# Altium schematic sheet UI.SchDoc
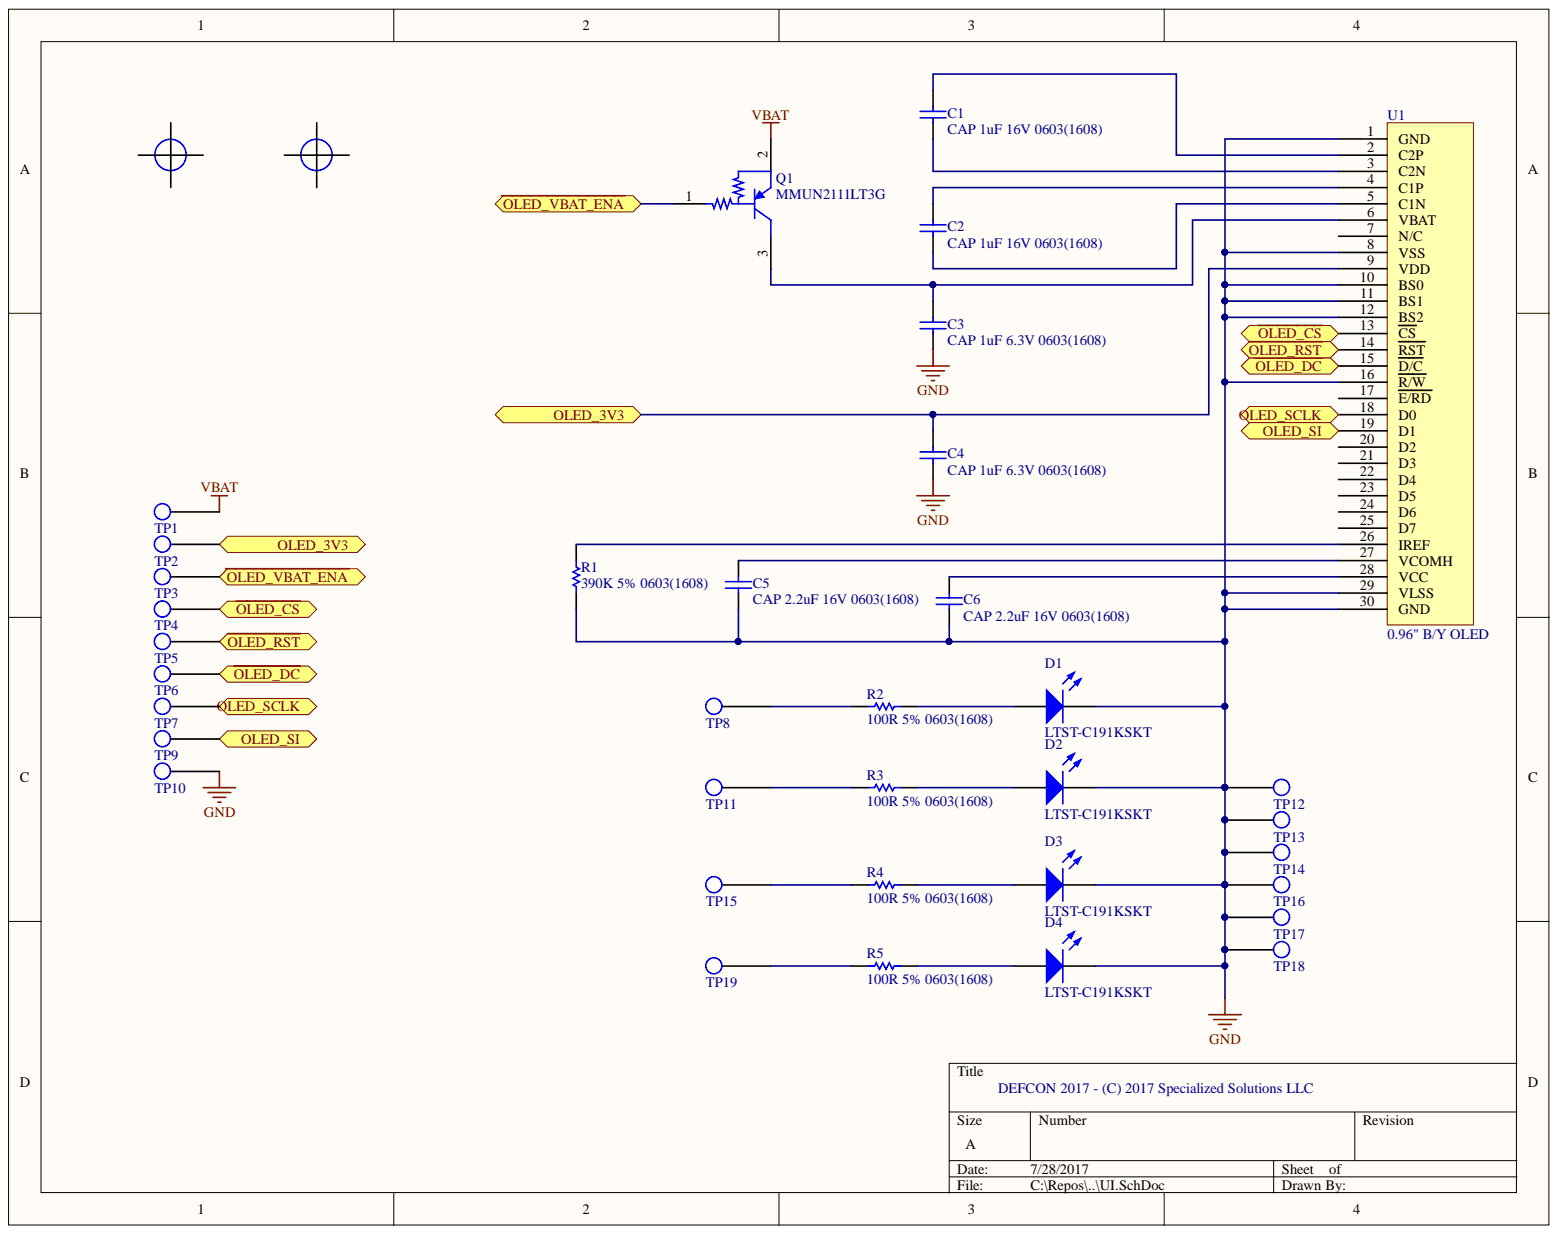
[diagram p0: UI.SchDoc]

## Parts
C1  CAP 1uF 16V 0603(1608)  value 1uF  [CAPC0603(1608)100_L]
C2  CAP 1uF 16V 0603(1608)  value 1uF  [CAPC0603(1608)100_L]
C3  CAP 1uF 6.3V 0603(1608)  value 1uF  [CAPC0603(1608)100_L]
C4  CAP 1uF 6.3V 0603(1608)  value 1uF  [CAPC0603(1608)100_L]
C5  CAP 2.2uF 16V 0603(1608)  value 2.2uF  [CAPC0603(1608)55_L]
C6  CAP 2.2uF 16V 0603(1608)  value 2.2uF  [CAPC0603(1608)55_L]
D1  LTST-C191KSKT  [DIOC1608X07N]
D2  LTST-C191KSKT  [DIOC1608X07N]
D3  LTST-C191KSKT  [DIOC1608X07N]
D4  LTST-C191KSKT  [DIOC1608X07N]
Q1  MMUN2111LT3G  [ONSC-SOT-23-3-318-08_V]
R1  390K 5% 0603(1608)  [RESC0603(1608)_N]
R2  100R 5% 0603(1608)  [RESC0603(1608)_L]
R3  100R 5% 0603(1608)  [RESC0603(1608)_L]
R4  100R 5% 0603(1608)  [RESC0603(1608)_L]
R5  100R 5% 0603(1608)  [RESC0603(1608)_L]
TP1  Test Point  [TP Castellation]  (value not drawn)  (no BOM)
TP2  Test Point  [TP Castellation]  (value not drawn)  (no BOM)
TP3  Test Point  [TP Castellation]  (value not drawn)  (no BOM)
TP4  Test Point  [TP Castellation]  (value not drawn)  (no BOM)
TP5  Test Point  [TP Castellation]  (value not drawn)  (no BOM)
TP6  Test Point  [TP Castellation]  (value not drawn)  (no BOM)
TP7  Test Point  [TP Castellation]  (value not drawn)  (no BOM)
TP8  Test Point  [TP Castellation]  (value not drawn)  (no BOM)
TP9  Test Point  [TP Castellation]  (value not drawn)  (no BOM)
TP10  Test Point  [TP Castellation]  (value not drawn)  (no BOM)
TP11  Test Point  [TP Castellation]  (value not drawn)  (no BOM)
TP12  Test Point  [TP Castellation]  (value not drawn)  (no BOM)
TP13  Test Point  [TP Castellation]  (value not drawn)  (no BOM)
TP14  Test Point  [TP Castellation]  (value not drawn)  (no BOM)
TP15  Test Point  [TP Castellation]  (value not drawn)  (no BOM)
TP16  Test Point  [TP Castellation]  (value not drawn)  (no BOM)
TP17  Test Point  [TP Castellation]  (value not drawn)  (no BOM)
TP18  Test Point  [TP Castellation]  (value not drawn)  (no BOM)
TP19  Test Point  [TP Castellation]  (value not drawn)  (no BOM)
U1  0.96" B/Y OLED  [0.96" B/Y OLED]
  Fiducial  [Fiducial]  (value not drawn)  (no BOM)
  Fiducial  [Fiducial]  (value not drawn)  (no BOM)

## Ports
OLED_3V3, OLED_SCLK, OLED_SI, ~{OLED_CS}, ~{OLED_DC}, ~{OLED_RST}, ~{OLED_VBAT_ENA}

## Nets
GND: C3.1 C4.1 C5.1 C6.1 D1.1 D2.1 D3.1 D4.1 R1.1 TP10.1 TP12.1 TP13.1 TP14.1 TP16.1 TP17.1 TP18.1 U1.1(GND) U1.8(VSS) U1.10(BS0) U1.11(BS1) U1.12(BS2) U1.16(R/W) U1.29(VLSS) U1.30(GND)
VBAT: Q1.2 TP1.1
~{OLED_CS}: TP4.1 U1.13(CS) ; port ~{OLED_CS}
~{OLED_DC}: TP6.1 U1.15(D/C) ; port ~{OLED_DC}
~{OLED_RST}: TP5.1 U1.14(RST) ; port ~{OLED_RST}
~{OLED_VBAT_ENA}: Q1.1 TP3.1 ; port ~{OLED_VBAT_ENA}
OLED_3V3: C4.2 TP2.1 U1.9(VDD) ; port OLED_3V3
OLED_SCLK: TP7.1 U1.18(D0) ; port OLED_SCLK
OLED_SI: TP9.1 U1.19(D1) ; port OLED_SI
NetC1_1: C1.1 U1.3(C2N)
NetC1_2: C1.2 U1.2(C2P)
NetC2_1: C2.1 U1.5(C1N)
NetC2_2: C2.2 U1.4(C1P)
NetC3_2: C3.2 Q1.3 U1.6(VBAT)
NetC5_2: C5.2 U1.27(VCOMH)
NetC6_2: C6.2 U1.28(VCC)
NetD1_2: D1.2 R2.2
NetD2_2: D2.2 R3.2
NetD3_2: D3.2 R4.2
NetD4_2: D4.2 R5.2
NetR1_2: R1.2 U1.26(IREF)
NetR2_1: R2.1 TP8.1
NetR3_1: R3.1 TP11.1
NetR4_1: R4.1 TP15.1
NetR5_1: R5.1 TP19.1

Not connected: U1 7(N/C) 17(E/RD) 20(D2) 21(D3) 22(D4) 23(D5) 24(D6) 25(D7)

## Library data of the parts
C1 C2 = CMP-1035-02738-1: CAP 1uF 16V ±20% 0603 (1608 Metric) Thickness 1mm SMD; Case-EIA: 0603; Case-Metric: 1608; Tolerance: Â±20%; Component Kind: Standard; Library Name: Altium Content Vault; Max Thickness: 1 mm; Rated Voltage: 16 V; Technology: SMT
C3 C4 = CMP-1035-02731-1: CAP 1uF 6.3V ±20% 0603 (1608 Metric) Thickness 1mm SMD; Case-EIA: 0603; Case-Metric: 1608; Tolerance: Â±20%; Component Kind: Standard; Library Name: Altium Content Vault; Max Thickness: 1 mm; Rated Voltage: 6.3 V; Technology: SMT
C5 C6 = CMP-1035-02764-1: CAP 2.2uF 16V ±20% 0603 (1608 Metric) Thickness 0.55mm SMD; Case-EIA: 0603; Case-Metric: 1608; Tolerance: Â±20%; Component Kind: Standard; Library Name: Altium Content Vault; Max Thickness: 0.55 mm; Rated Voltage: 16 V; Technology: SMT
D1-D4 = LTST-C191KSKT: Yellow 591nm LED Indication - Discrete 2.1V 0603 (1608 Metric); Component Kind: Standard; Library Name: Parts.SchLib
Q1 = CMP-0630-00255-1: Digital Transistor (BRT), R1=10 kOhm, R2=10 kOhm, PNP Transistor, 3-Pin SOT-23, Pb-Free, Tape and Reel; Manufacturer: On Semiconductor; hFE Min: 35; IC Continuous (A): 0.1; R1/R2 Typ: 1; V(BR)CEO Min (V): 50; Component Kind: Standard; ComponentLink1Description: Manufacturer URL; ComponentLink1URL: http://www.onsemi.com/; ComponentLink2Description: Datasheet; ComponentLink2URL: http://www.onsemi.cn/pub_link/Collateral/DTA114E-D.PDF; ComponentLink3Description: Package Specification; ComponentLink3URL: http://www.onsemi.cn/pub_link/Collateral/318-08.PDF; DatasheetVersion: Rev. 7; Library Name: Altium Content Vault; Mounting Technology: Surface Mount; PackageDescription: 3-Pin Small Outline Transistor, Body 2.92 x 1.3 mm, Pitch 0.95 mm; PackageReference: SOT-23-3-318-08; PackageVersion: Rev. AP, 11/2009; Packing: Tape and Reel; PartNumber: MMUN2111LT3G; Polarity: PNP; RoHS: TRUE
R1 = CMP-1012-00136-1: 390K 0.1W 5% 0603 (1608 Metric)  SMD; Case-EIA: 0603; Case-Metric: 1608; Value: 390000.00 Ohm; Component Kind: Standard; Library Name: Altium Content Vault; Power: 0.1 W; Technology: SMT; Tolerance: 5 %
R2-R5 = CMP-1012-00050-1: 100R 0.1W 5% 0603 (1608 Metric)  SMD; Case-EIA: 0603; Case-Metric: 1608; Value: 100.00 Ohm; Component Kind: Standard; Library Name: Altium Content Vault; Power: 0.1 W; Technology: SMT; Tolerance: 5 %
TP1-TP19 = TP Castellation: Description: TP Castellation; Library Name: Parts.SchLib
U1 = 0.96" B/Y OLED: 0.96" Blue/Yellow OLED 128x64 SSD1306; Component Kind: Standard; Library Name: Parts.SchLib
  = Fiducial: Library Name: Parts.SchLib
